# Altium schematic sheet Schematic.SchDoc(Schematic)
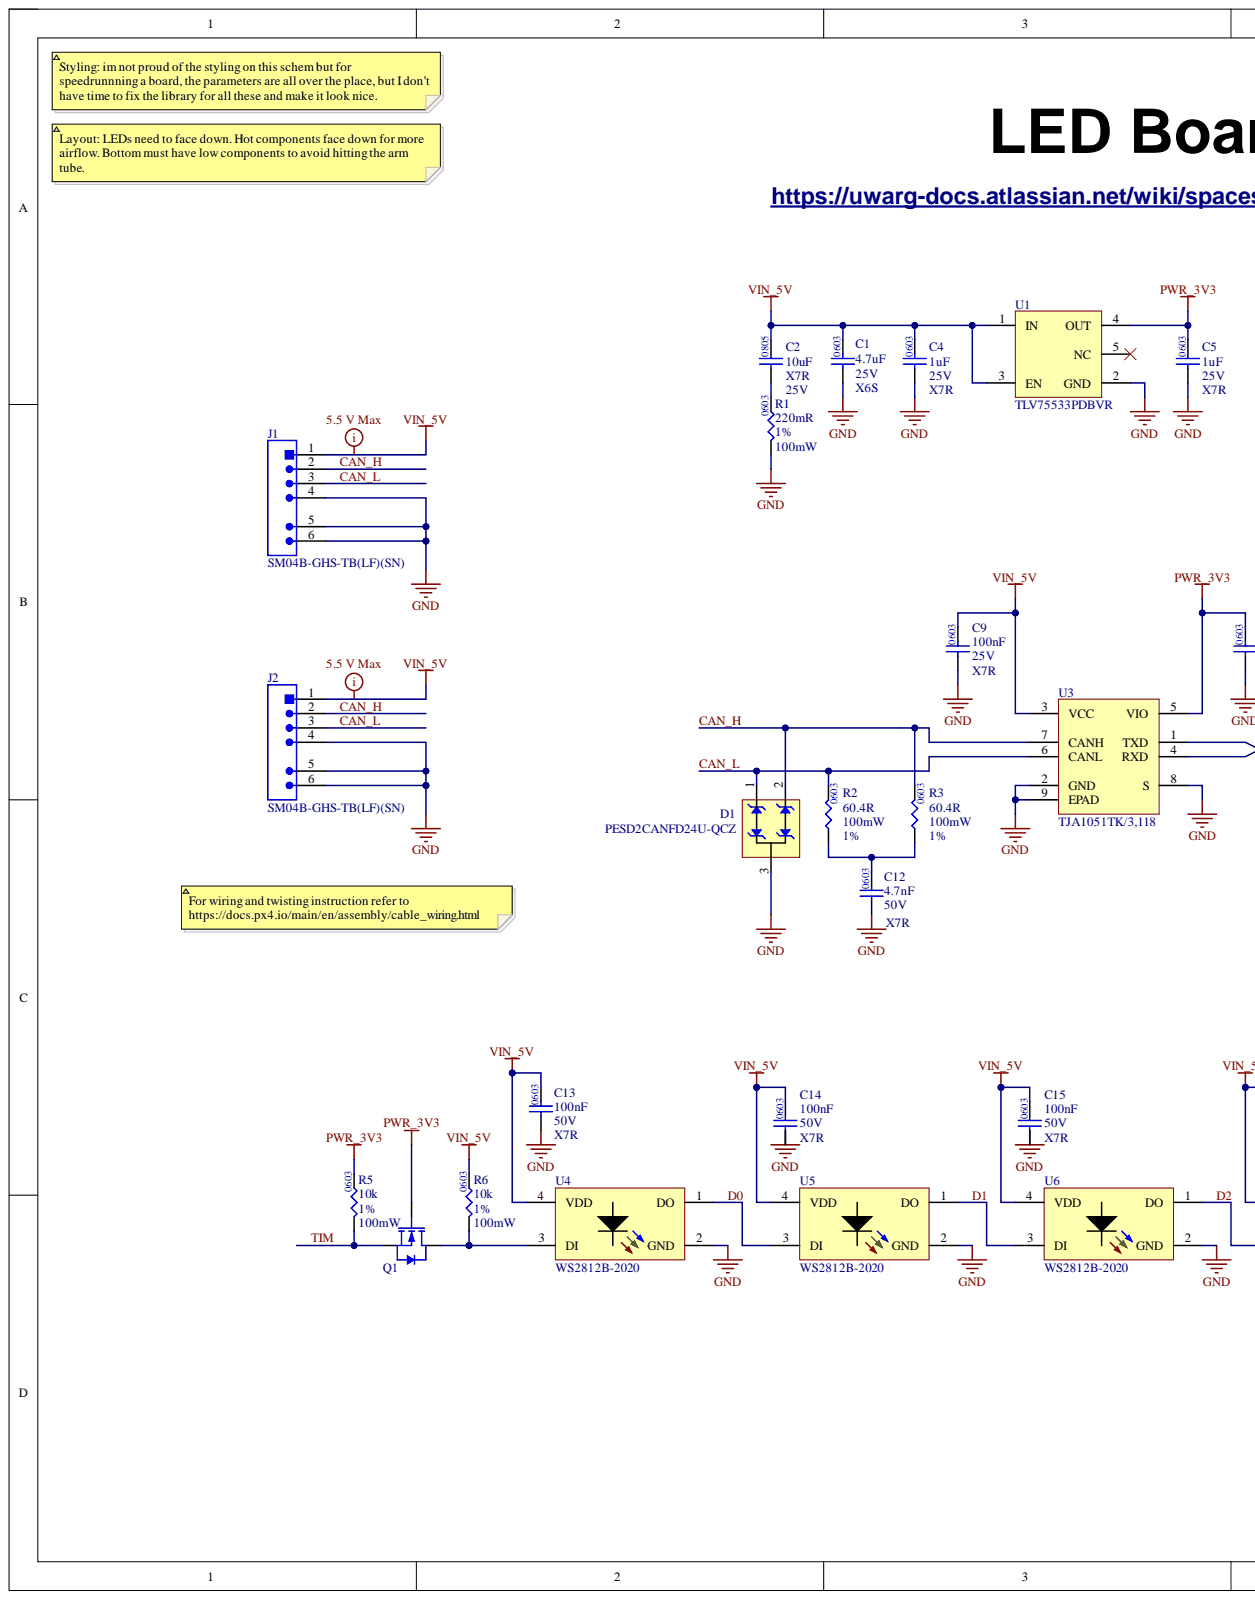
[diagram p0: Schematic.SchDoc, left]
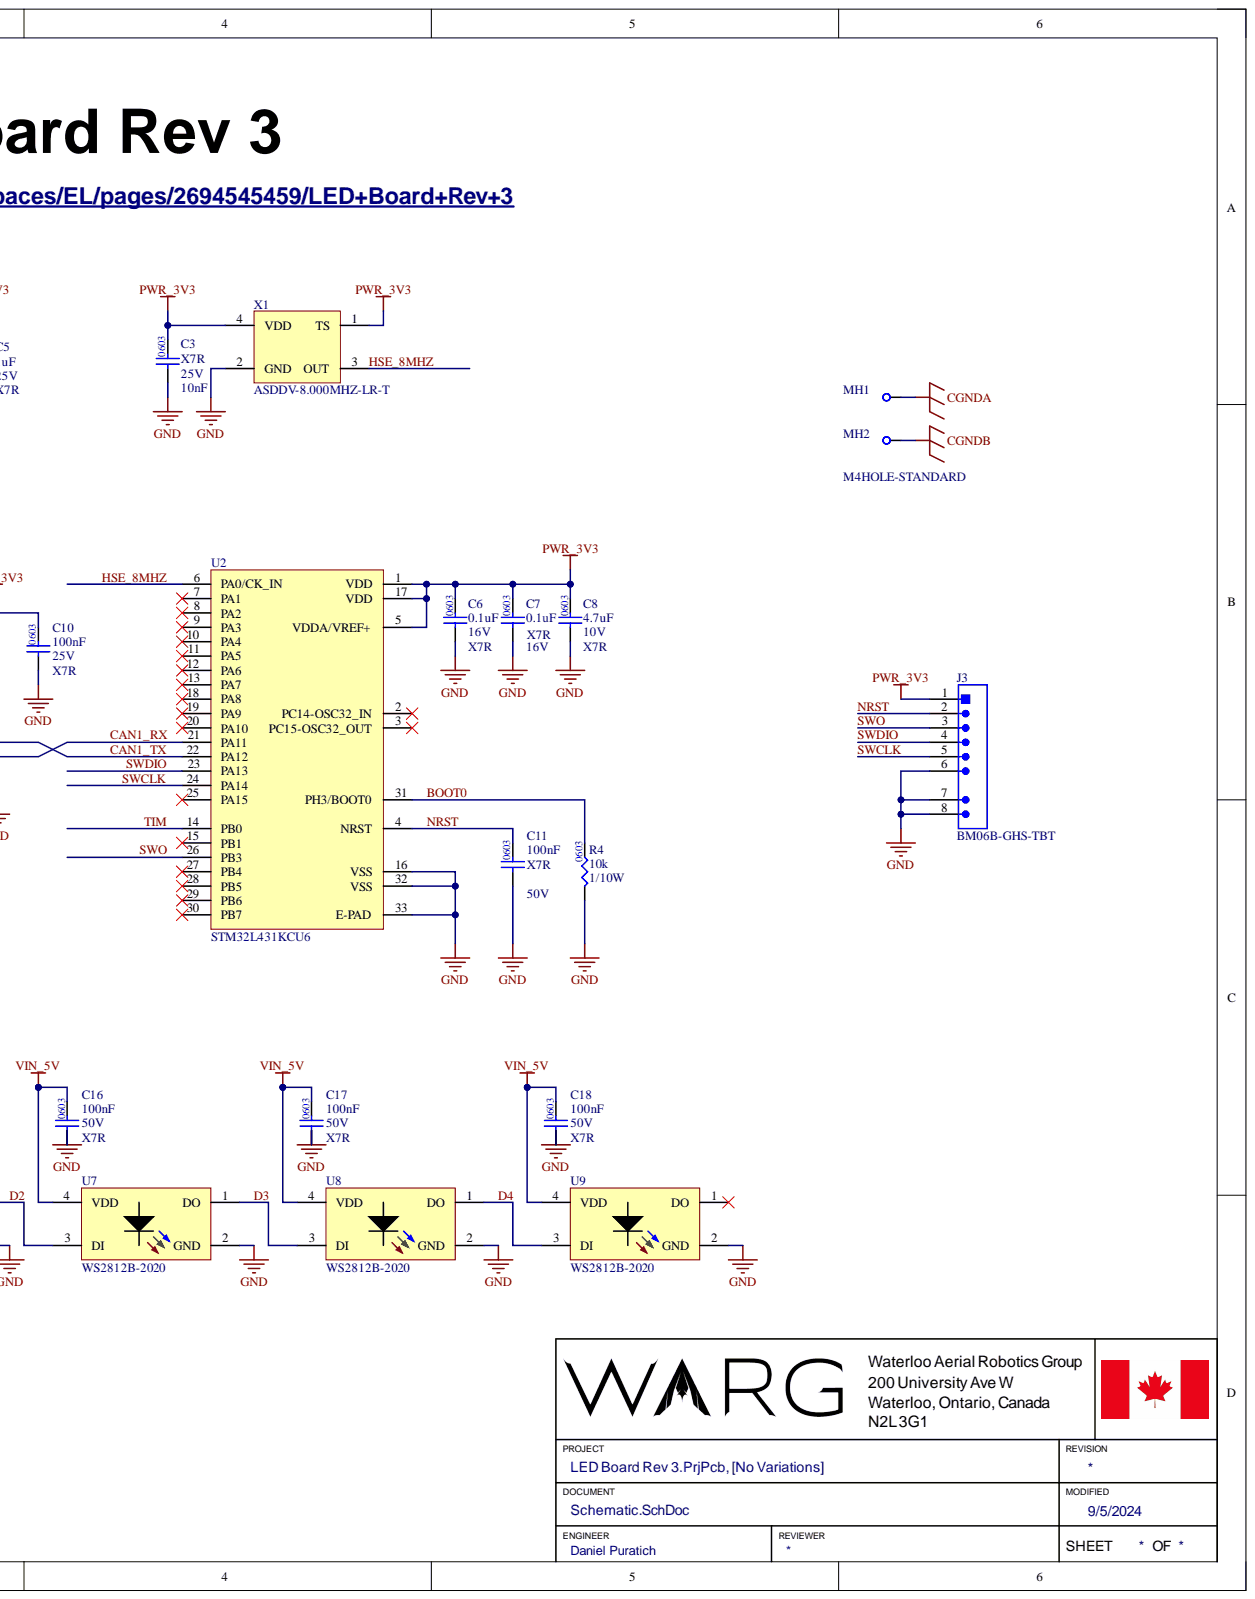
[diagram p1: Schematic.SchDoc, right]

## Parts
C1  value 4.7uF  Capacitance: 4.7uF  Dielectric: X6S  Package: 0603  Voltage: 25V  [.CAP_0603-Footprint-1]  (hidden comment: GRM188C81E475KE11D)
C2  Capacitance: 10uF  Temperature Coefficient: X7R  Voltage Rating: 25V  [.CAP_0805-Footprint-1]  (hidden comment: GMC21X7R106K25NT)
C3  Capacitance: 10nF  Package: 0603  Temperature Coefficient: X7R  Voltage Rating: 25V  [.CAP_0603-Footprint-1]  (hidden comment: CC0603KRX7R8BB103)
C4  Capacitance: 1uF  Temperature Coefficient: X7R  Voltage Rating: 25V  [.CAP_0603-Footprint-1]  (hidden comment: GCM188R71E105KA64D)
C5  Capacitance: 1uF  Temperature Coefficient: X7R  Voltage Rating: 25V  [.CAP_0603-Footprint-1]  (hidden comment: GCM188R71E105KA64D)
C6  value 0.1uF  Package: 0603  Temperature Coefficient: X7R  Voltage: 16V  [.CAP_0603-Footprint-1]  (hidden comment: GCM188R71C104KA37D)
C7  value 0.1uF  Package: 0603  Temperature Coefficient: X7R  Voltage: 16V  [.CAP_0603-Footprint-1]  (hidden comment: GCM188R71C104KA37D)
C8  value 4.7uF  Capacitance: 4.7uF  Package: 0603  Temperature Coefficient: X7R  Voltage: 10V  [.CAP_0603-Footprint-1]  (hidden comment: GRM188Z71A475KE15J)
C9  Capacitance: 100nF  Case/Package: 0603  Dielectric: X7R  Voltage Rating: 25V  [.CAP_0603-Footprint-1]  (hidden comment: CL10B104KA8NNNC)
C10  Capacitance: 100nF  Case/Package: 0603  Dielectric: X7R  Voltage Rating: 25V  [.CAP_0603-Footprint-1]  (hidden comment: CL10B104KA8NNNC)
C11  Capacitance: 100nF  Dielectric: X7R  Package: 0603  Voltage: 50V  [.CAP_0603-Footprint-1]  (hidden comment: CL10B104KB8NNNC)
C12  value 4.7nF  Case/Package: 0603  Rated DC Voltage (URdc): 50V  Temperature Characteristics Code: X7R  [.CAP_0603-Footprint-1]  (hidden comment: GCM188R71H472KA37D)
C13  Capacitance: 100nF  Dielectric: X7R  Package: 0603  Voltage: 50V  [.CAP_0603-Footprint-1]  (hidden comment: CL10B104KB8NNNC)
C14  Capacitance: 100nF  Dielectric: X7R  Package: 0603  Voltage: 50V  [.CAP_0603-Footprint-1]  (hidden comment: CL10B104KB8NNNC)
C15  Capacitance: 100nF  Dielectric: X7R  Package: 0603  Voltage: 50V  [.CAP_0603-Footprint-1]  (hidden comment: CL10B104KB8NNNC)
C16  Capacitance: 100nF  Dielectric: X7R  Package: 0603  Voltage: 50V  [.CAP_0603-Footprint-1]  (hidden comment: CL10B104KB8NNNC)
C17  Capacitance: 100nF  Dielectric: X7R  Package: 0603  Voltage: 50V  [.CAP_0603-Footprint-1]  (hidden comment: CL10B104KB8NNNC)
C18  Capacitance: 100nF  Dielectric: X7R  Package: 0603  Voltage: 50V  [.CAP_0603-Footprint-1]  (hidden comment: CL10B104KB8NNNC)
D1  PESD2CANFD24U-QCZ  [PESD2CANFD24U-QCZ-Footprint-1]
J1  SM04B-GHS-TB(LF)(SN)  [CONN_SM04B-GHS-TB_JST]
J2  SM04B-GHS-TB(LF)(SN)  [CONN_SM04B-GHS-TB_JST]
J3  BM06B-GHS-TBT  [BM06B-GHS-TBT-Footprint-1]
MH1  M4HOLE-STANDARD  [M4HOLE-STANDARD-Footprint-1]
MH2  M4HOLE-STANDARD  [M4HOLE-STANDARD-Footprint-1]
Q1  BSS138  [BSS138-Footprint-1]  (value not drawn)
R1  value 220mR  Case/Package: 0603  Power: 100mW  Tolerance: 1%  [.RES_0603-Footprint-1]  (hidden comment: RL0603FR-070R22L)
R2  value 60.4R  Power: 100mW  Tolerance: 1%  [RES0603]  (hidden comment: RC0603FR-1360R4L)
R3  value 60.4R  Power: 100mW  Tolerance: 1%  [RES0603]  (hidden comment: RC0603FR-1360R4L)
R4  value 10k  Package: 0603  Power: 1/10W  Resistance: 10k  [.RES_0603-Footprint-1]  (hidden comment: RC0603FR-0710KL)
R5  Package: 0603  Power: 100mW  Resistance: 10k  Tolerance: 1%  [.RES_0603-Footprint-1]  (hidden comment: AC0603FR-1310KL)
R6  Package: 0603  Power: 100mW  Resistance: 10k  Tolerance: 1%  [.RES_0603-Footprint-1]  (hidden comment: AC0603FR-1310KL)
U1  TLV75533PDBVR  [SOT-23-5]
U2  STM32L431KCU6  [STM32L431KCU6-Footprint-1]
U3  TJA1051TK/3,118  [TJA1051TK_3,118-Footprint-1]
U4  WS2812B-2020  [WS2812B-2020-Footprint-1]
U5  WS2812B-2020  [WS2812B-2020-Footprint-1]
U6  WS2812B-2020  [WS2812B-2020-Footprint-1]
U7  WS2812B-2020  [WS2812B-2020-Footprint-1]
U8  WS2812B-2020  [WS2812B-2020-Footprint-1]
U9  WS2812B-2020  [WS2812B-2020-Footprint-1]
X1  ASDDV-8.000MHZ-LR-T  [ASDDV-8.000MHZ-LR-T-Footprint-1]

## Nets
CGNDA: MH1.1
CGNDB: MH2.1
GND: C1.1 C3.1 C4.1 C5.1 C6.1 C7.1 C8.1 C9.1 C10.1 C11.1 C12.1 C13.1 C14.1 C15.1 C16.1 C17.1 C18.1 D1.3 J1.4 J1.5 J1.6 J2.4 J2.5 J2.6 J3.6 J3.7 J3.8 R1.1 R4.1 U1.2(GND) U2.16(VSS) U2.32(VSS) U2.33(E-PAD) U3.2(GND) U3.8(S) U3.9(EPAD) U4.2(GND) U5.2(GND) U6.2(GND) U7.2(GND) U8.2(GND) U9.2(GND) X1.2(GND)
PWR_3V3: C3.2 C5.2 C6.2 C7.2 C8.2 C10.2 J3.1 Q1.G R5.2 U1.4(OUT) U2.1(VDD) U2.5(VDDA/VREF+) U2.17(VDD) U3.5(VIO) X1.1(TS) X1.4(VDD)
VIN_5V: C1.2 C2.2 C4.2 C9.2 C13.2 C14.2 C15.2 C16.2 C17.2 C18.2 J1.1 J2.1 R6.2 U1.1(IN) U1.3(EN) U3.3(VCC) U4.4(VDD) U5.4(VDD) U6.4(VDD) U7.4(VDD) U8.4(VDD) U9.4(VDD)
BOOT0: R4.2 U2.31(PH3/BOOT0)
CAN1_RX: U2.21(PA11) U3.4(RXD)
CAN1_TX: U2.22(PA12) U3.1(TXD)
CAN_H: D1.2 J1.2 J2.2 R3.2 U3.7(CANH)
CAN_L: D1.1 J1.3 J2.3 R2.2 U3.6(CANL)
D0: U4.1(DO) U5.3(DI)
D1: U5.1(DO) U6.3(DI)
D2: U6.1(DO) U7.3(DI)
D3: U7.1(DO) U8.3(DI)
D4: U8.1(DO) U9.3(DI)
HSE_8MHZ: U2.6(PA0/CK_IN) X1.3(OUT)
NRST: C11.2 J3.2 U2.4(NRST)
SWCLK: J3.5 U2.24(PA14)
SWDIO: J3.4 U2.23(PA13)
SWO: J3.3 U2.26(PB3)
TIM: Q1.S R5.1 U2.14(PB0)
NetC2_1: C2.1 R1.2
NetC12_2: C12.2 R2.1 R3.1
NetQ1_D: Q1.D R6.1 U4.3(DI)

Not connected: U1 5(NC); U2 2(PC14-OSC32_IN) 3(PC15-OSC32_OUT) 7(PA1) 8(PA2) 9(PA3) 10(PA4) 11(PA5) 12(PA6) 13(PA7) 15(PB1) 18(PA8) 19(PA9) 20(PA10) 25(PA15) 27(PB4) 28(PB5) 29(PB6) 30(PB7); U9 1(DO)

## Library data of the parts
C1 = CMP-001-00002-10: CAP CER 4.7uF 25V X6S 0603; Component Kind: Standard; Library Name: WARG
C2 = CMP-00241-5: CAP CER 10UF 25V X7R 0805; Tolerance: 10%; Component Kind: Standard; Library Name: WARG
C3 = CMP-00217-1: CAP CER 10nF 25V X7R 0603; Component Kind: Standard; Library Name: WARG
C4 C5 = CMP-00240-2: CAP CER 1UF 25V X7R 0603; Tolerance: 10%; Component Kind: Standard; Mounting Technology: Surface Mount; Library Name: WARG
C6 C7 = CMP-001-00003-9: CAP CER 100nF 16V X7R 0603; Capacitance: 100nF; Component Kind: Standard; Library Name: WARG
C8 = CMP-001-00045-2: CAP CER 4.7UF 10V X7R 0603; Component Kind: Standard; Library Name: WARG
C9 C10 = CMP-00057-2: CAP CER 0.1UF 25V X7R 0603; Tolerance: 10%; Component Kind: Standard; Library Name: WARG
C11 C13-C18 = CMP-00018-8: CAP CER 0.1uF 50V X7R 0603; Component Kind: Standard; Library Name: WARG
C12 = CMP-001-00080-1: CAP CER 4700PF 50V X7R 0603; Capacitor Type: Ceramic; Multilayer: Yes; Reference Standard: AEC-Q200; Tolerance  (fill in as +-): 10%; Component Kind: Standard; Dielectric Material: Ceramic; Height: 0.9mm; JESD-609 Code: e3; Length: 1.6mm; Max Operating Temperature: 125°C; Min Operating Temperature: -55°C; Mounting Technology: Surface Mount; Package Shape: Rectangular; Package Style: SMTMeter; Packaging: Tape and Reel; Pins: 2; Reach SVHC Compliant: Yes; RoHS Compliant: Yes; Terminal Finish: Nickel; Termination: Wraparound; Tolerance (fill in as +-): 10%; Width: 0.8mm; Library Name: WARG
D1 = CMP-00239-5: TVS DIODE 24VWM 43VC DFN1412D-3; Component Kind: Standard; Library Name: WARG
J1 J2 = CMP-068-000000-5: Conn Shrouded Header HDR 4 POS 1.25mm Solder RA SMD Reel; Entry Type: R/A; Component Kind: Standard; Pins: 4; Series: JST-GH; Library Name: WARG
J3 = CMP-002-00014-9: Connector Header Surface Mount 6 position 0.049" (1.25mm); Entry Type: Vert; Component Kind: Standard; Pins: 6; Series: JST-GH; Library Name: WARG
MH1 MH2 = CMP-00191-1: Standard M4 Hole; Component Kind: Standard; Library Name: WARG
Q1 = CMP-00295-3: MOSFET N-CH 50V 220MA SOT23-3; Component Kind: Standard; Library Name: WARG
R1 = CMP-00261-1: RES 0.22 OHM 1% 1/10W 0603; Component Kind: Standard; Library Name: WARG
R2 R3 = CMP-00245-1: RES 60.4 OHM 1% 1/10W 0603; Component Kind: Standard; Library Name: WARG
R4 = CMP-009-00006-5: RES 10K OHM 1% 1/10W 0603; Component Kind: Standard; Tolerance: 1%; Library Name: WARG
R5 R6 = CMP-009-00054-3: RES 10K OHM 1% 1/10W 0603; Component Kind: Standard; Library Name: WARG
U1 = CMP-00225-4: IC REG LINEAR 3.3V 500MA SOT23-5; Component Kind: Standard; Library Name: WARG
U2 = CMP-00224-6: IC MCU 32BIT 256KB FLSH 32UFQFPN; Component Kind: Standard; Library Name: WARG
U3 = CMP-00219-3: IC TRANSCEIVER HALF 1/1 8HVSON; Component Kind: Standard; Library Name: WARG
U4-U9 = CMP-00294-3: LED Neopixel 2mm; Component Kind: Standard; Library Name: WARG
X1 = CMP-00242-3: OSC XO 8.000MHZ CMOS SMD; Frequence Stability: 25ppm; Frequency: 8MHz; Component Kind: Standard; Library Name: WARG
